# Altium schematic sheet WiFi.SchDoc
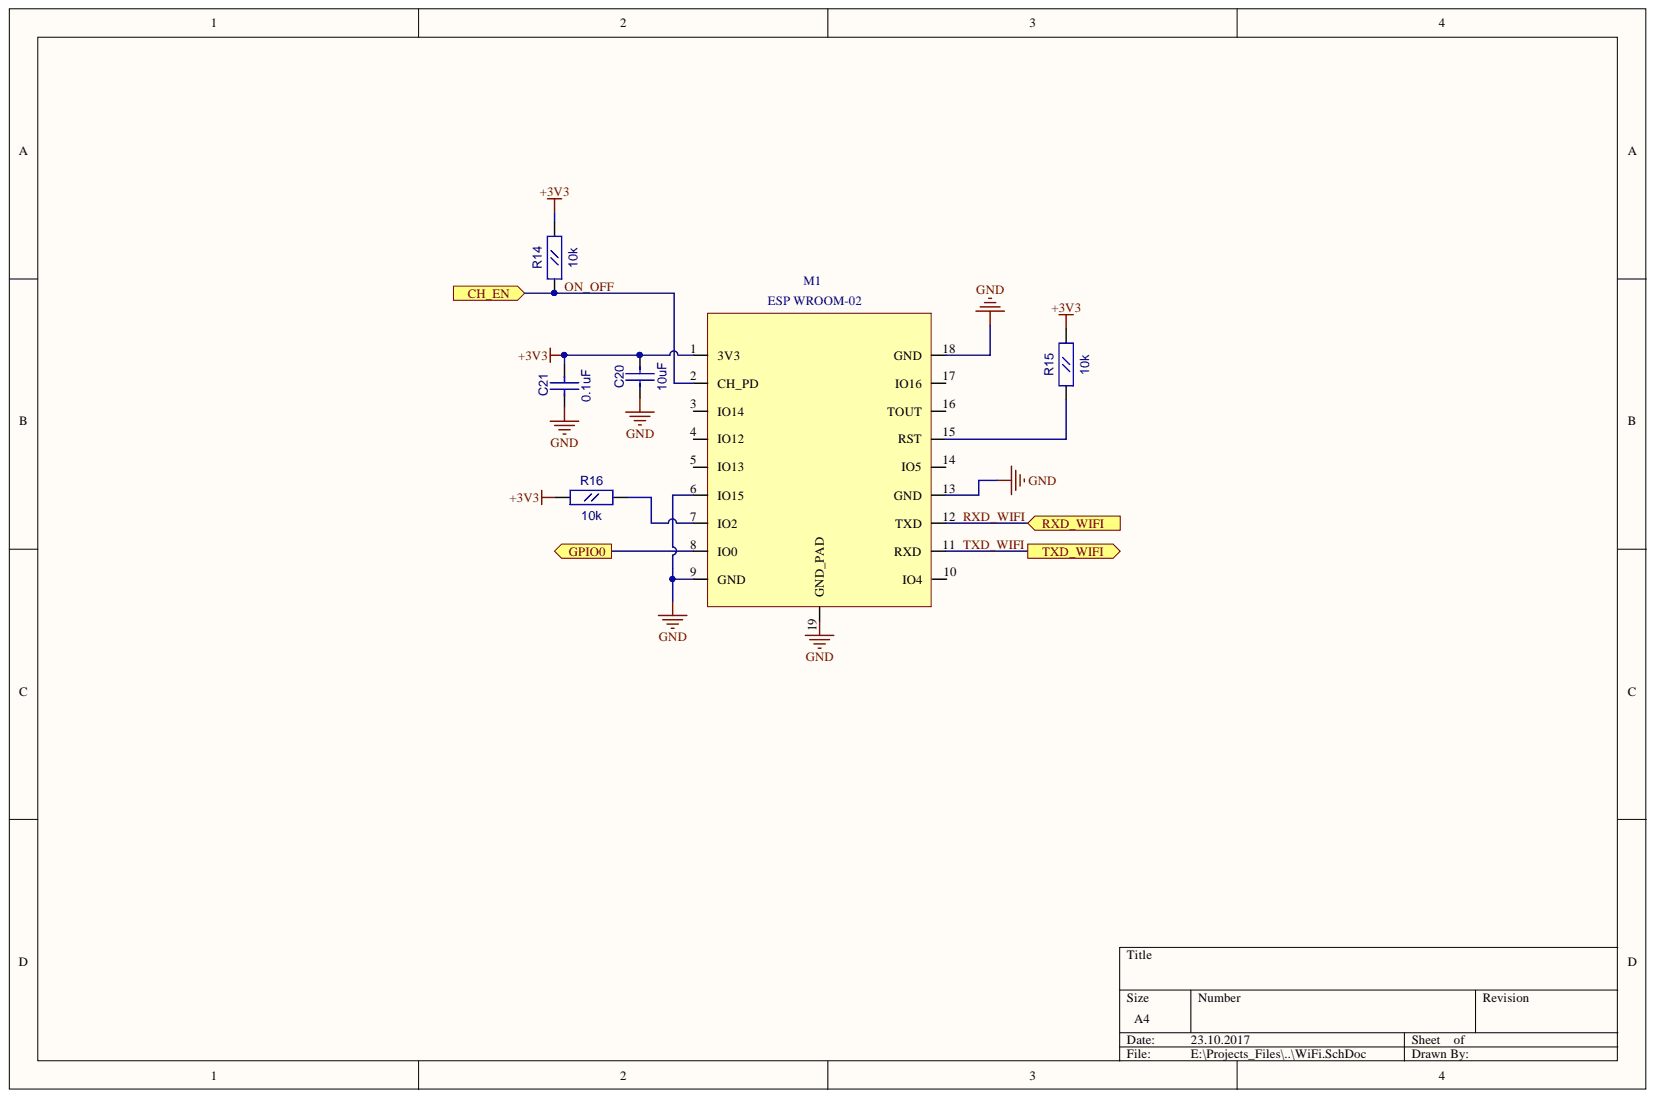
[diagram p0: WiFi.SchDoc]

## Parts
C20  10uF  [CC0805]
C21  0.1uF  [CC0603]
M1  ESP WROOM-02  [ESP-WOORM-02]
R14  10k  [RC0603]
R15  10k  [RC0603]
R16  10k  [RC0603]

## Ports
CH_EN, GPIO0, RXD_WIFI, TXD_WIFI

## Nets
+3V3: C20.2 C21.2 M1.1(3V3) R14.2 R15.2 R16.1
GND: C20.1 C21.1 M1.6(IO15) M1.9(GND) M1.13(GND) M1.18(GND) M1.19(GND_PAD)
GPIO0: M1.8(IO0) ; port GPIO0
ON_OFF: M1.2(CH_PD) R14.1 ; port CH_EN
RXD_WIFI: M1.12(TXD) ; port RXD_WIFI
TXD_WIFI: M1.11(RXD) ; port TXD_WIFI
NetM1_7: M1.7(IO2) R16.2
NetM1_15: M1.15(RST) R15.1

Not connected: M1 3(IO14) 4(IO12) 5(IO13) 10(IO4) 14(IO5) 16(TOUT) 17(IO16)

## Library data of the parts
C20 = CC0805: Component Kind: Standard; Description: CC0805; Value: 10uF; Library Name: gprs_modem_2.SchLib
C21 = CC0603: Component Kind: Standard; Description: CC0603; Value: 0.1uF; Library Name: gprs_modem_2.SchLib
M1 = ESP-WROOM-02: Component Kind: Standard; Value: ESP WROOM-02; Library Name: gprs_modem_2.SchLib
R14-R16 = RC0603: Component Kind: Standard; Description: RC0603; Value: 10k; Library Name: gprs_modem_2.SchLib
